# Altium schematic sheet Motor Drivers 2.SchDoc
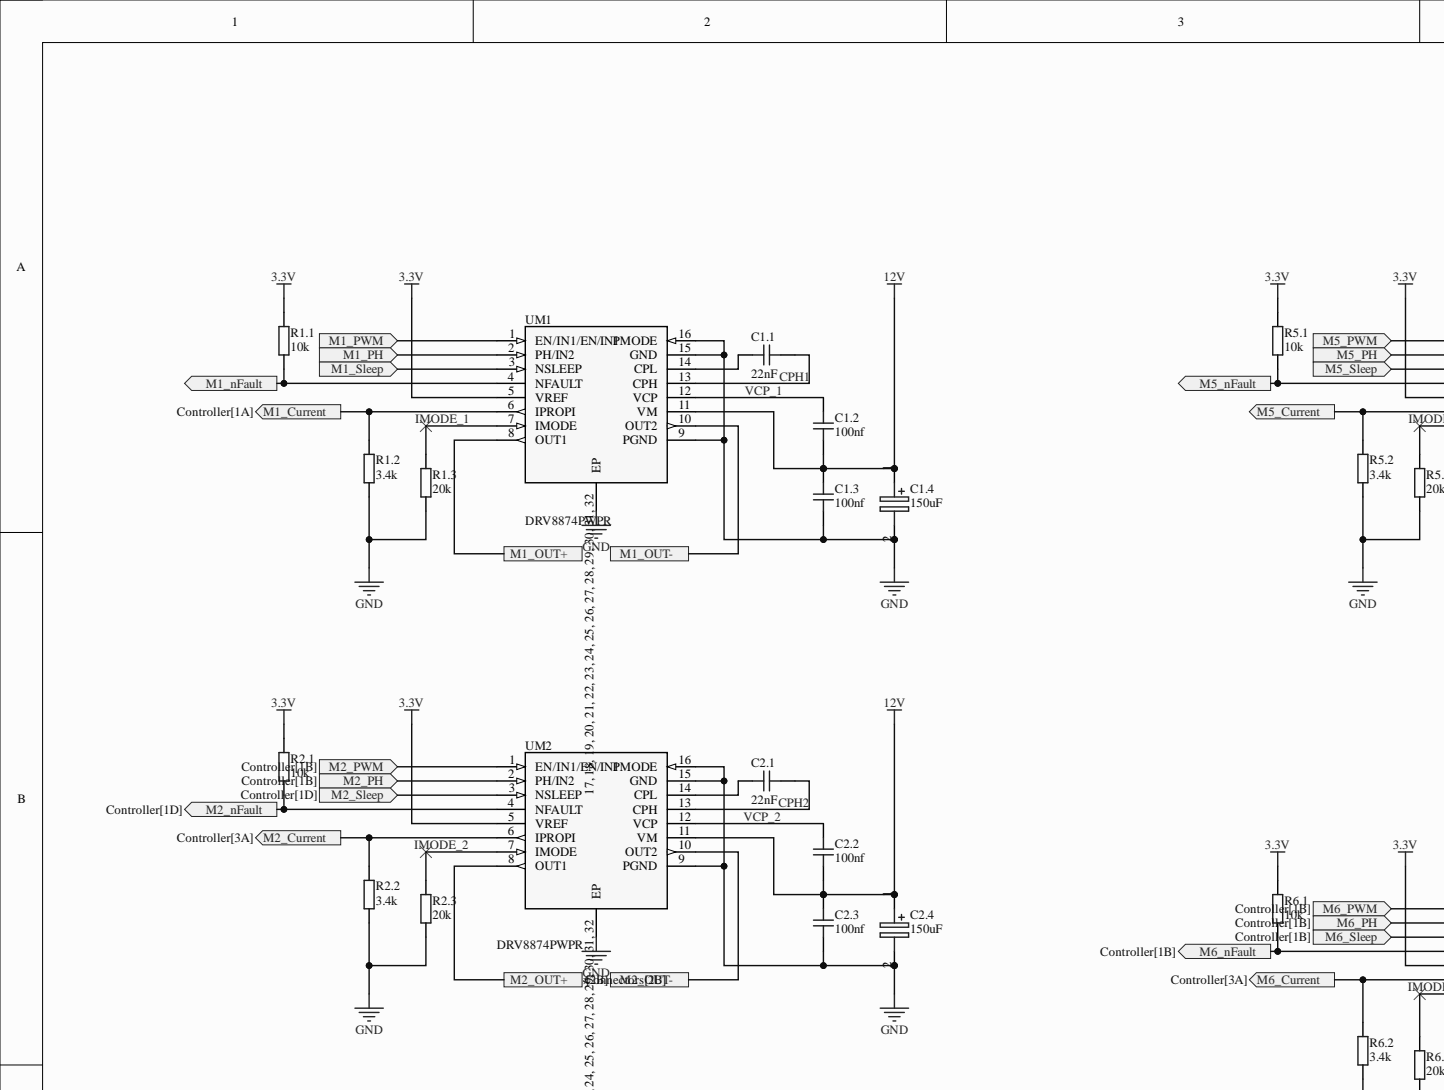
[diagram p0: Motor Drivers 2.SchDoc, top left]
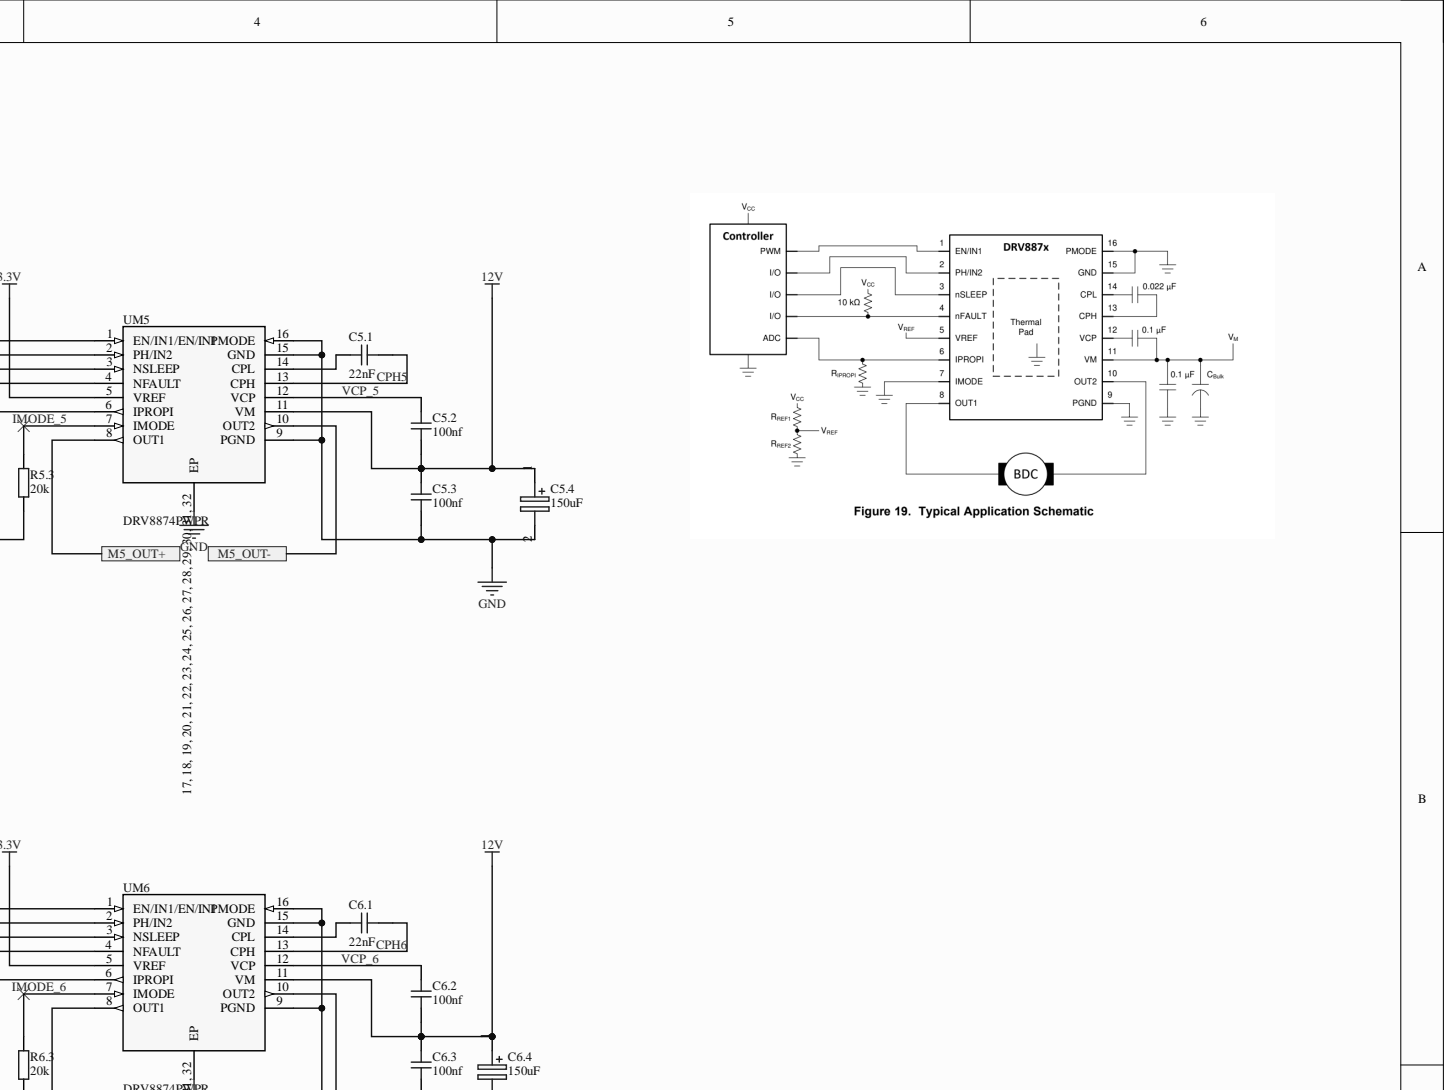
[diagram p1: Motor Drivers 2.SchDoc, top right]
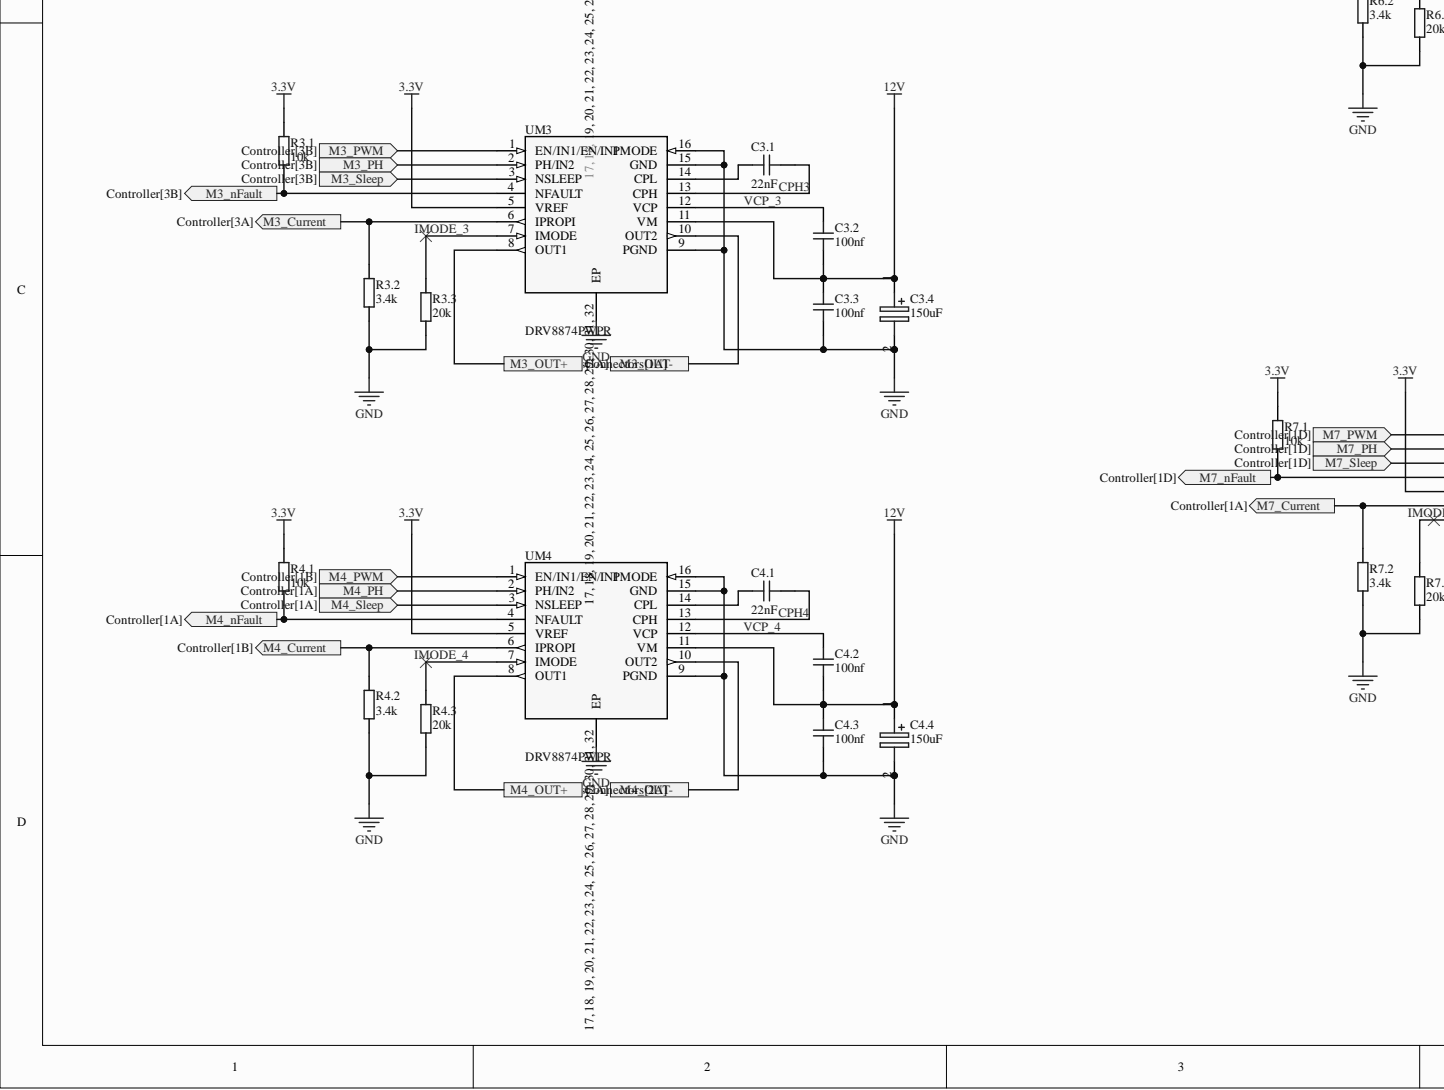
[diagram p2: Motor Drivers 2.SchDoc, bottom left]
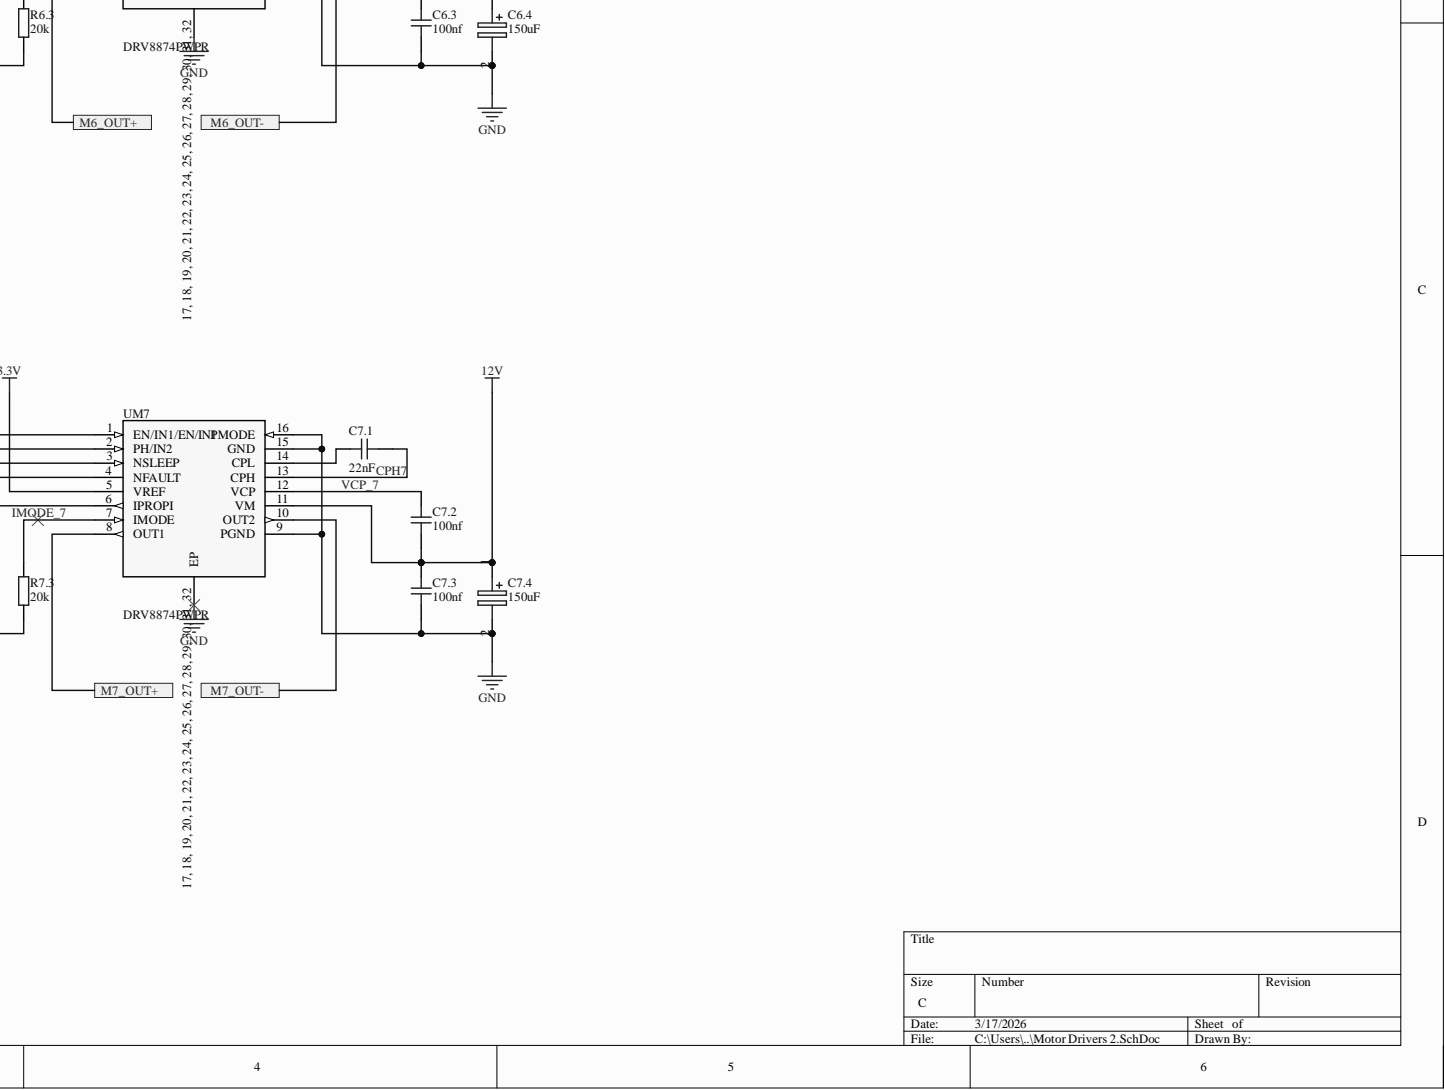
[diagram p3: Motor Drivers 2.SchDoc, bottom right]

## Parts
C1.1  22nF  [CAP_0402]
C1.2  100nf  [CAP_0402]
C1.3  100nf  [CAP_0402]
C1.4  150uF  [CAPPRD350W65D800H1250]
C2.1  22nF  [CAP_0402]
C2.2  100nf  [CAP_0402]
C2.3  100nf  [CAP_0402]
C2.4  150uF  [CAPPRD350W65D800H1250]
C3.1  22nF  [CAP_0402]
C3.2  100nf  [CAP_0402]
C3.3  100nf  [CAP_0402]
C3.4  150uF  [CAPPRD350W65D800H1250]
C4.1  22nF  [CAP_0402]
C4.2  100nf  [CAP_0402]
C4.3  100nf  [CAP_0402]
C4.4  150uF  [CAPPRD350W65D800H1250]
C5.1  22nF  [CAP_0402]
C5.2  100nf  [CAP_0402]
C5.3  100nf  [CAP_0402]
C5.4  150uF  [CAPPRD350W65D800H1250]
C6.1  22nF  [CAP_0402]
C6.2  100nf  [CAP_0402]
C6.3  100nf  [CAP_0402]
C6.4  150uF  [CAPPRD350W65D800H1250]
C7.1  22nF  [CAP_0402]
C7.2  100nf  [CAP_0402]
C7.3  100nf  [CAP_0402]
C7.4  150uF  [CAPPRD350W65D800H1250]
R1.1  10k  [RES_0402]
R1.2  3.4k  [RES_0402]
R1.3  20k  [RES_0402]
R2.1  10k  [RES_0402]
R2.2  3.4k  [RES_0402]
R2.3  20k  [RES_0402]
R3.1  10k  [RES_0402]
R3.2  3.4k  [RES_0402]
R3.3  20k  [RES_0402]
R4.1  10k  [RES_0402]
R4.2  3.4k  [RES_0402]
R4.3  20k  [RES_0402]
R5.1  10k  [RES_0402]
R5.2  3.4k  [RES_0402]
R5.3  20k  [RES_0402]
R6.1  10k  [RES_0402]
R6.2  3.4k  [RES_0402]
R6.3  20k  [RES_0402]
R7.1  10k  [RES_0402]
R7.2  3.4k  [RES_0402]
R7.3  20k  [RES_0402]
UM1  DRV8874PWPR  [PWP0016J_L]
UM2  DRV8874PWPR  [PWP0016J_L]
UM3  DRV8874PWPR  [PWP0016J_L]
UM4  DRV8874PWPR  [PWP0016J_L]
UM5  DRV8874PWPR  [PWP0016J_L]
UM6  DRV8874PWPR  [PWP0016J_L]
UM7  DRV8874PWPR  [PWP0016J_L]

## Ports
M1_Current, M1_nFault, M1_OUT+, M1_OUT-, M1_PH, M1_PWM, M1_Sleep, M2_Current, M2_nFault, M2_OUT+, M2_OUT-, M2_PH, M2_PWM, M2_Sleep, M3_Current, M3_nFault, M3_OUT+, M3_OUT-, M3_PH, M3_PWM, M3_Sleep, M4_Current, M4_nFault, M4_OUT+, M4_OUT-, M4_PH, M4_PWM, M4_Sleep, M5_Current, M5_nFault, M5_OUT+, M5_OUT-, M5_PH, M5_PWM, M5_Sleep, M6_Current, M6_nFault, M6_OUT+, M6_OUT-, M6_PH, M6_PWM, M6_Sleep, M7_Current, M7_nFault, M7_OUT+, M7_OUT-, M7_PH, M7_PWM, M7_Sleep

## Nets
3.3V: R1.1.2 R2.1.2 R3.1.2 R4.1.2 R5.1.2 R6.1.2 R7.1.2 UM1.5(VREF) UM2.5(VREF) UM3.5(VREF) UM4.5(VREF) UM5.5(VREF) UM6.5(VREF) UM7.5(VREF)
12V: C1.2.2 C1.3.1 C1.4.1 C2.2.2 C2.3.1 C2.4.1 C3.2.2 C3.3.1 C3.4.1 C4.2.2 C4.3.1 C4.4.1 C5.2.2 C5.3.1 C5.4.1 C6.2.2 C6.3.1 C6.4.1 C7.2.2 C7.3.1 C7.4.1 UM1.11(VM) UM2.11(VM) UM3.11(VM) UM4.11(VM) UM5.11(VM) UM6.11(VM) UM7.11(VM)
GND: C1.3.2 C1.4.2 C2.3.2 C2.4.2 C3.3.2 C3.4.2 C4.3.2 C4.4.2 C5.3.2 C5.4.2 C6.3.2 C6.4.2 C7.3.2 C7.4.2 R1.2.1 R1.3.1 R2.2.1 R2.3.1 R3.2.1 R3.3.1 R4.2.1 R4.3.1 R5.2.1 R5.3.1 R6.2.1 R6.3.1 R7.2.1 R7.3.1 UM1.9(PGND) UM1.15(GND) UM1.16(PMODE) UM1.17, 18, 19, 20, 21, 22, 23, 24, 25, 26, 27, 28, 29, 30, 31, 32(EP) UM2.9(PGND) UM2.15(GND) UM2.16(PMODE) UM2.17, 18, 19, 20, 21, 22, 23, 24, 25, 26, 27, 28, 29, 30, 31, 32(EP) UM3.9(PGND) UM3.15(GND) UM3.16(PMODE) UM3.17, 18, 19, 20, 21, 22, 23, 24, 25, 26, 27, 28, 29, 30, 31, 32(EP) UM4.9(PGND) UM4.15(GND) UM4.16(PMODE) UM4.17, 18, 19, 20, 21, 22, 23, 24, 25, 26, 27, 28, 29, 30, 31, 32(EP) UM5.9(PGND) UM5.15(GND) UM5.16(PMODE) UM5.17, 18, 19, 20, 21, 22, 23, 24, 25, 26, 27, 28, 29, 30, 31, 32(EP) UM6.9(PGND) UM6.15(GND) UM6.16(PMODE) UM6.17, 18, 19, 20, 21, 22, 23, 24, 25, 26, 27, 28, 29, 30, 31, 32(EP) UM7.9(PGND) UM7.15(GND) UM7.16(PMODE) UM7.17, 18, 19, 20, 21, 22, 23, 24, 25, 26, 27, 28, 29, 30, 31, 32(EP)
CPH1: C1.1.2 UM1.13(CPH)
CPH2: C2.1.2 UM2.13(CPH)
CPH3: C3.1.2 UM3.13(CPH)
CPH4: C4.1.2 UM4.13(CPH)
CPH5: C5.1.2 UM5.13(CPH)
CPH6: C6.1.2 UM6.13(CPH)
CPH7: C7.1.2 UM7.13(CPH)
IMODE_1: R1.3.2 UM1.7(IMODE)
IMODE_2: R2.3.2 UM2.7(IMODE)
IMODE_3: R3.3.2 UM3.7(IMODE)
IMODE_4: R4.3.2 UM4.7(IMODE)
IMODE_5: R5.3.2 UM5.7(IMODE)
IMODE_6: R6.3.2 UM6.7(IMODE)
IMODE_7: R7.3.2 UM7.7(IMODE)
M1_Current: R1.2.2 UM1.6(IPROPI) ; port M1_Current
M1_nFault: R1.1.1 UM1.4(NFAULT) ; port M1_nFault
M1_OUT+: UM1.8(OUT1) ; port M1_OUT+
M1_OUT-: UM1.10(OUT2) ; port M1_OUT-
M1_PH: UM1.2(PH/IN2) ; port M1_PH
M1_PWM: UM1.1(EN/IN1/EN/IN1) ; port M1_PWM
M1_Sleep: UM1.3(NSLEEP) ; port M1_Sleep
M2_Current: R2.2.2 UM2.6(IPROPI) ; port M2_Current
M2_nFault: R2.1.1 UM2.4(NFAULT) ; port M2_nFault
M2_OUT+: UM2.8(OUT1) ; port M2_OUT+
M2_OUT-: UM2.10(OUT2) ; port M2_OUT-
M2_PH: UM2.2(PH/IN2) ; port M2_PH
M2_PWM: UM2.1(EN/IN1/EN/IN1) ; port M2_PWM
M2_Sleep: UM2.3(NSLEEP) ; port M2_Sleep
M3_Current: R3.2.2 UM3.6(IPROPI) ; port M3_Current
M3_nFault: R3.1.1 UM3.4(NFAULT) ; port M3_nFault
M3_OUT+: UM3.8(OUT1) ; port M3_OUT+
M3_OUT-: UM3.10(OUT2) ; port M3_OUT-
M3_PH: UM3.2(PH/IN2) ; port M3_PH
M3_PWM: UM3.1(EN/IN1/EN/IN1) ; port M3_PWM
M3_Sleep: UM3.3(NSLEEP) ; port M3_Sleep
M4_Current: R4.2.2 UM4.6(IPROPI) ; port M4_Current
M4_nFault: R4.1.1 UM4.4(NFAULT) ; port M4_nFault
M4_OUT+: UM4.8(OUT1) ; port M4_OUT+
M4_OUT-: UM4.10(OUT2) ; port M4_OUT-
M4_PH: UM4.2(PH/IN2) ; port M4_PH
M4_PWM: UM4.1(EN/IN1/EN/IN1) ; port M4_PWM
M4_Sleep: UM4.3(NSLEEP) ; port M4_Sleep
M5_Current: R5.2.2 UM5.6(IPROPI) ; port M5_Current
M5_nFault: R5.1.1 UM5.4(NFAULT) ; port M5_nFault
M5_OUT+: UM5.8(OUT1) ; port M5_OUT+
M5_OUT-: UM5.10(OUT2) ; port M5_OUT-
M5_PH: UM5.2(PH/IN2) ; port M5_PH
M5_PWM: UM5.1(EN/IN1/EN/IN1) ; port M5_PWM
M5_Sleep: UM5.3(NSLEEP) ; port M5_Sleep
M6_Current: R6.2.2 UM6.6(IPROPI) ; port M6_Current
M6_nFault: R6.1.1 UM6.4(NFAULT) ; port M6_nFault
M6_OUT+: UM6.8(OUT1) ; port M6_OUT+
M6_OUT-: UM6.10(OUT2) ; port M6_OUT-
M6_PH: UM6.2(PH/IN2) ; port M6_PH
M6_PWM: UM6.1(EN/IN1/EN/IN1) ; port M6_PWM
M6_Sleep: UM6.3(NSLEEP) ; port M6_Sleep
M7_Current: R7.2.2 UM7.6(IPROPI) ; port M7_Current
M7_nFault: R7.1.1 UM7.4(NFAULT) ; port M7_nFault
M7_OUT+: UM7.8(OUT1) ; port M7_OUT+
M7_OUT-: UM7.10(OUT2) ; port M7_OUT-
M7_PH: UM7.2(PH/IN2) ; port M7_PH
M7_PWM: UM7.1(EN/IN1/EN/IN1) ; port M7_PWM
M7_Sleep: UM7.3(NSLEEP) ; port M7_Sleep
VCP_1: C1.2.1 UM1.12(VCP)
VCP_2: C2.2.1 UM2.12(VCP)
VCP_3: C3.2.1 UM3.12(VCP)
VCP_4: C4.2.1 UM4.12(VCP)
VCP_5: C5.2.1 UM5.12(VCP)
VCP_6: C6.2.1 UM6.12(VCP)
VCP_7: C7.2.1 UM7.12(VCP)
NetC1.1_1: C1.1.1 UM1.14(CPL)
NetC2.1_1: C2.1.1 UM2.14(CPL)
NetC3.1_1: C3.1.1 UM3.14(CPL)
NetC4.1_1: C4.1.1 UM4.14(CPL)
NetC5.1_1: C5.1.1 UM5.14(CPL)
NetC6.1_1: C6.1.1 UM6.14(CPL)
NetC7.1_1: C7.1.1 UM7.14(CPL)

## Library data of the parts
C1.1-C1.3 C2.1-C2.3 C3.1-C3.3 C4.1-C4.3 C5.1-C5.3 C6.1-C6.3 C7.1-C7.3 = CAP_0402: Capacitor SMD 0402; Component Kind: Standard; Library Name: Schematic-Lib-PhilsLab.SchLib
C1.4 C2.4 C3.4 C4.4 C5.4 C6.4 C7.4 = EEU-FM1V151: Capacitor Polarised; Manufacturer_Name: Panasonic; Arrow Part Number: EEU-FM1V151; Arrow Price/Stock: https://www.arrow.com/en/products/eeu-fm1v151/panasonic; Component Kind: Standard; Datasheet Link: http://industrial.panasonic.com/cdbs/www-data/pdf/RDF0000/ABA0000C1018.pdf; Height: 12.5mm; Manufacturer_Part_Number: EEU-FM1V151; Mouser Part Number: 667-EEU-FM1V151; Mouser Price/Stock: https://www.mouser.co.uk/ProductDetail/Panasonic/EEU-FM1V151?qs=zA3IriytwbbdlQclkT52ag%3D%3D
R1.1-R1.3 R2.1-R2.3 R3.1-R3.3 R4.1-R4.3 R5.1-R5.3 R6.1-R6.3 R7.1-R7.3 = RES_0402: Resistor SMD 0402; Component Kind: Standard; Library Name: Schematic-Lib-PhilsLab.SchLib
UM1-UM7 = DRV8874PWPR: 40-V, 6-A H-bridge motor driver with integrated current sensing feedback 16-HTSSOP -40 to 125; Manufacturer_Name: Texas Instruments; Component Kind: Standard; Datasheet: https://www.ti.com/lit/gpn/drv8874; Digi-Key_Part_Number_1: 296-DRV8874PWPRTR-ND; Digi-Key_Part_Number_2: 296-DRV8874PWPRCT-ND; Digi-Key_Part_Number_3: 296-DRV8874PWPRDKR-ND; Library Name: DRV8874PWPR.SchLib; Manufacturer_Part_Number: DRV8874PWPR; RefDes: RefDes; Supplier 1: Digikey; Supplier Part Number 1: 296-DRV8874PWPRCT-ND; TYPE: TYPE; Copyright: Copyright (C) 2025 Ultra Librarian. All rights reserved.
